# Altium schematic sheet Supply.SchDoc(Supply)
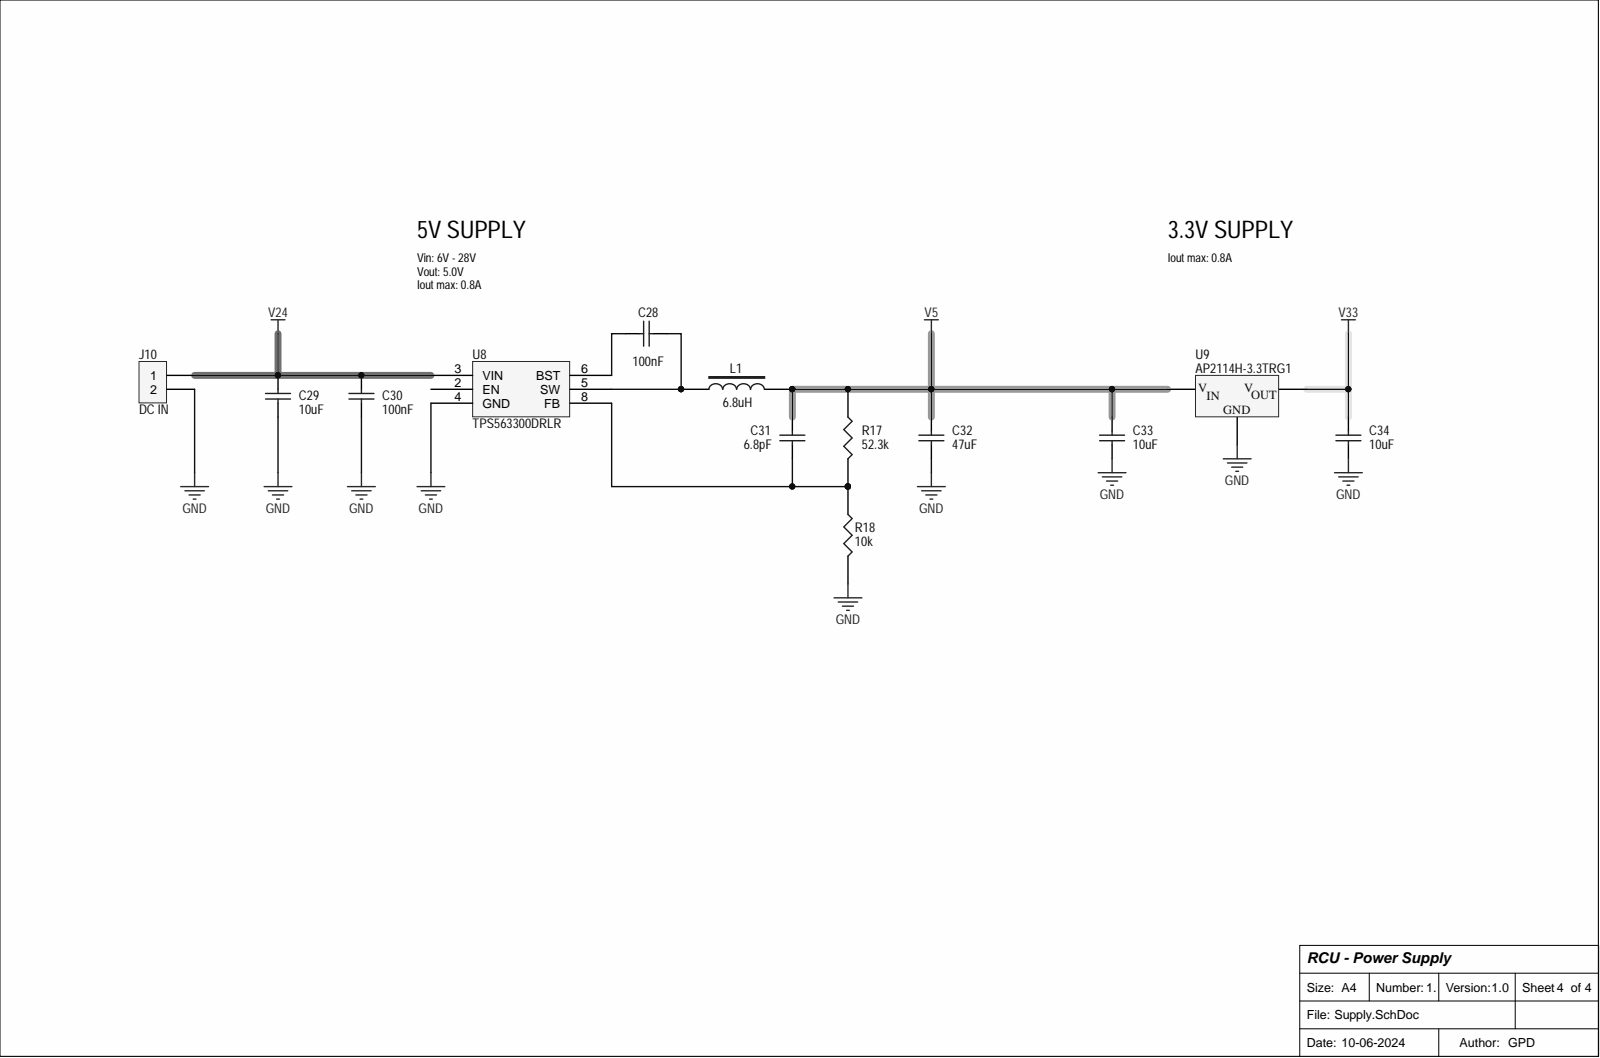
[diagram p0: Supply.SchDoc]

## Parts
C28  100nF  [C0603]
C29  10uF  [C1206]
C30  100nF  [C0603]
C31  6.8pF  [C0402]
C32  47uF  [C1210]
C33  10uF  [C0603]
C34  10uF  [C0603]
J10  DC IN  [SIP2]
L1  6.8uH  [COIL9]
R17  52.3k  [R0402]
R18  10k  [R0402]
U8  TPS563300DRLR  [SOT-5X3 - 0.6 mm]
U9  AP2114H-3.3TRG1  [DSO-G3/C6.6]

## Nets
GND: C29.2 C30.2 C32.1 C33.2 C34.2 J10.2 R18.2 U8.4(GND) U9.1
V5: C31.1 C32.2 C33.1 L1.2 R17.1 U9.3
V24: C29.1 C30.1 J10.1 U8.3(VIN)
V33: C34.1 U9.2
NetC28_1: C28.1 U8.6(BST)
NetC28_2: C28.2 L1.1 U8.5(SW)
NetC31_2: C31.2 R17.2 R18.1 U8.8(FB)

Not connected: U8 2(EN)

## Library data of the parts
C28 C30 = CAP: Multilayer Ceramic Capacitor, 0.1 uF, 50 V, ± 10%, X7R, 0603 [1608 Metric]; Manufacturer Part Number: CC0603KPX7R9BB104; Manufacturer: Yageo; Value: 100nF; Supplier Part Number 3: 603-CC603KPX7R9BB104; Supplier Part Number 2: 3764509RL; Supplier Part Number 1: 311-3567-6-ND; Supplier 3: Mouser; Supplier 2: Farnell; Supplier 1: Digikey; Library Name: Miscellaneous Devices.SchLib; Component Kind: Standard
C29 = CAP: Multilayer Ceramic Capacitor, 10 uF, 50 V, ± 20%, X5R, 1206 [3216 Metric]; Manufacturer Part Number: UMK316BBJ106ML-T; Manufacturer: Taiyo Yuden; Value: 10uF; Supplier Part Number 3: C97712; Supplier Part Number 2: 963-UMK316BBJ106ML-T; Supplier Part Number 1: 587-3248-6-ND; Supplier 3: LCSC; Supplier 2: Mouser; Supplier 1: Digikey; Library Name: Miscellaneous Devices.SchLib; Component Kind: Standard
C31 = CAP: Cap Ceramic 6.8pF 25V C0G 0.25pF SMD 0402 125C Paper T/R; Manufacturer Part Number: GRM1555C1E6R8CA01D; Manufacturer: Murata; Value: 6.8pF; Supplier Part Number 3: C3877782; Supplier Part Number 2: 81-GRM1555C1E6R8CA1D; Supplier Part Number 1: 490-11371-1-ND; Supplier 3: LCSC; Supplier 2: Mouser; Supplier 1: Digikey; Library Name: Miscellaneous Devices.SchLib; Component Kind: Standard
C32 = CAP: Multilayer Ceramic Capacitor, 47 uF, 16 V, ± 10%, X5R, 1210 [3225 Metric]; Manufacturer Part Number: CL32A476KOJNNNE; Manufacturer: Samsung; Value: 47uF; Supplier Part Number 3: 1276-3376-1-ND; Supplier Part Number 2: C2932477; Supplier Part Number 1: 187-CL32A476KOJNNNE; Supplier 3: Digikey; Supplier 2: LCSC; Supplier 1: Mouser; Library Name: Miscellaneous Devices.SchLib; Component Kind: Standard
C33 C34 = CAP: Multilayer Ceramic Capacitor, 10 uF, 16 V, ± 20%, X6S, 0603 [1608 Metric]; Manufacturer Part Number: GRM188C81C106MA73D; Manufacturer: Murata; Value: 10uF; Supplier Part Number 3: 81-GRM188C81C106MA3D; Supplier Part Number 2: 490-7198-1-ND; Supplier Part Number 1: C182375; Supplier 3: Mouser; Supplier 2: Digikey; Supplier 1: LCSC; Library Name: Miscellaneous Devices.SchLib; Component Kind: Standard
J10 = CON2: Header Assembly 2 Position MTA100 SPCL BEND MTA-100 Series | TE Connectivity 644874-2; Value: DC IN; Supplier Part Number 2: A114207-ND; Supplier Part Number 1: 571-644874-2; Supplier 2: Digikey; Supplier 1: Mouser; Manufacturer Part Number: 644874-2; Manufacturer: TE Connectivity; Library Name: Miscellaneous Devices.SchLib; Component Kind: Standard
L1 = INDUCTOR2: Power Choke Shielded Wirewound 6.8uH 20% 100KHz Ferrite 5.5A 22.2mOhm DCR T/R; Manufacturer Part Number: 7443340680; Manufacturer: Wurth Electronics; Value: 6.8uH; Supplier Part Number 3: 2211637; Supplier Part Number 2: 732-4182-6-ND; Supplier Part Number 1: 710-7443340680; Supplier 3: Farnell; Supplier 2: Digikey; Supplier 1: Mouser; Component Kind: Standard
R17 = RES1: Res Thin Film 0402 52.3K Ohm 0.1% 0.063W(1/16W) ±25ppm/C Pad SMD T/R; Manufacturer Part Number: RT0402BRD0752K3L; Manufacturer: Yageo; Value: 52.3k; Supplier Part Number 3: YAG1450CT-ND; Supplier Part Number 2: 603-RT0402BRD0752K3L; Supplier Part Number 1: YAG1450DKR-ND; Supplier 3: Digikey; Supplier 2: Mouser; Supplier 1: Digikey; Library Name: Miscellaneous Devices.SchLib; Component Kind: Standard
R18 = RES1: Res Thin Film 0402 10K Ohm 0.1% 0.063W(1/16W) ±50ppm/C Pad SMD T/R; Manufacturer Part Number: RT0402BRE0710KL; Manufacturer: Yageo; Value: 10k; Supplier Part Number 3: YAG2305CT-ND; Supplier Part Number 2: YAG2305DKR-ND; Supplier Part Number 1: 603-RT0402BRE0710KL; Supplier 3: Digikey; Supplier 2: Digikey; Supplier 1: Mouser; Library Name: Miscellaneous Devices.SchLib; Component Kind: Standard
U8 = TPS563300: 3.8-V to 28-V Input, 3-A synchronous buck converter in SOT-583 package 8-SOT-5X3 -40 to 150; Manufacturer: Texas Instruments; Value: TPS563300DRLR; Supplier Part Number 3: 296-TPS563300DRLRCT-ND; Supplier Part Number 2: 595-TPS563300DRLR; Supplier Part Number 1: TPS563300DRLR; Supplier 3: Digikey; Supplier 2: Mouser; Supplier 1: Texas Instruments; Manufacturer Part Number: TPS563300DRLR; Library Name: Power.SchLib; ComponentLink1URL: https://www.ti.com/lit/gpn/tps563300; ComponentLink1Description: Datasheet; Component Kind: Standard
U9 = 78L05: IC REG LDO 3.3V 1A SOT223; Manufacturer: Diodes; Value: AP2114H-3.3TRG1; Supplier Part Number 3: 621-AP2114H-3.3TRG1; Supplier Part Number 2: AP2114H-3.3TRG1DIDKR-ND; Supplier Part Number 1: C150716; Supplier 3: Mouser; Supplier 2: Digikey; Supplier 1: LCSC; Simulation: 78L05; Manufacturer Part Number: AP2114H-3.3TRG1; Library Name: REGULATOR.SchLib; ComponentLink1URL: https://datasheet.lcsc.com/lcsc/2304140030_Diodes-Incorporated-AP2114H-3-3TRG1_C150716.pdf; ComponentLink1Description: Datasheet; Component Kind: Standard
